# Altium schematic sheet motor_control.SchDoc
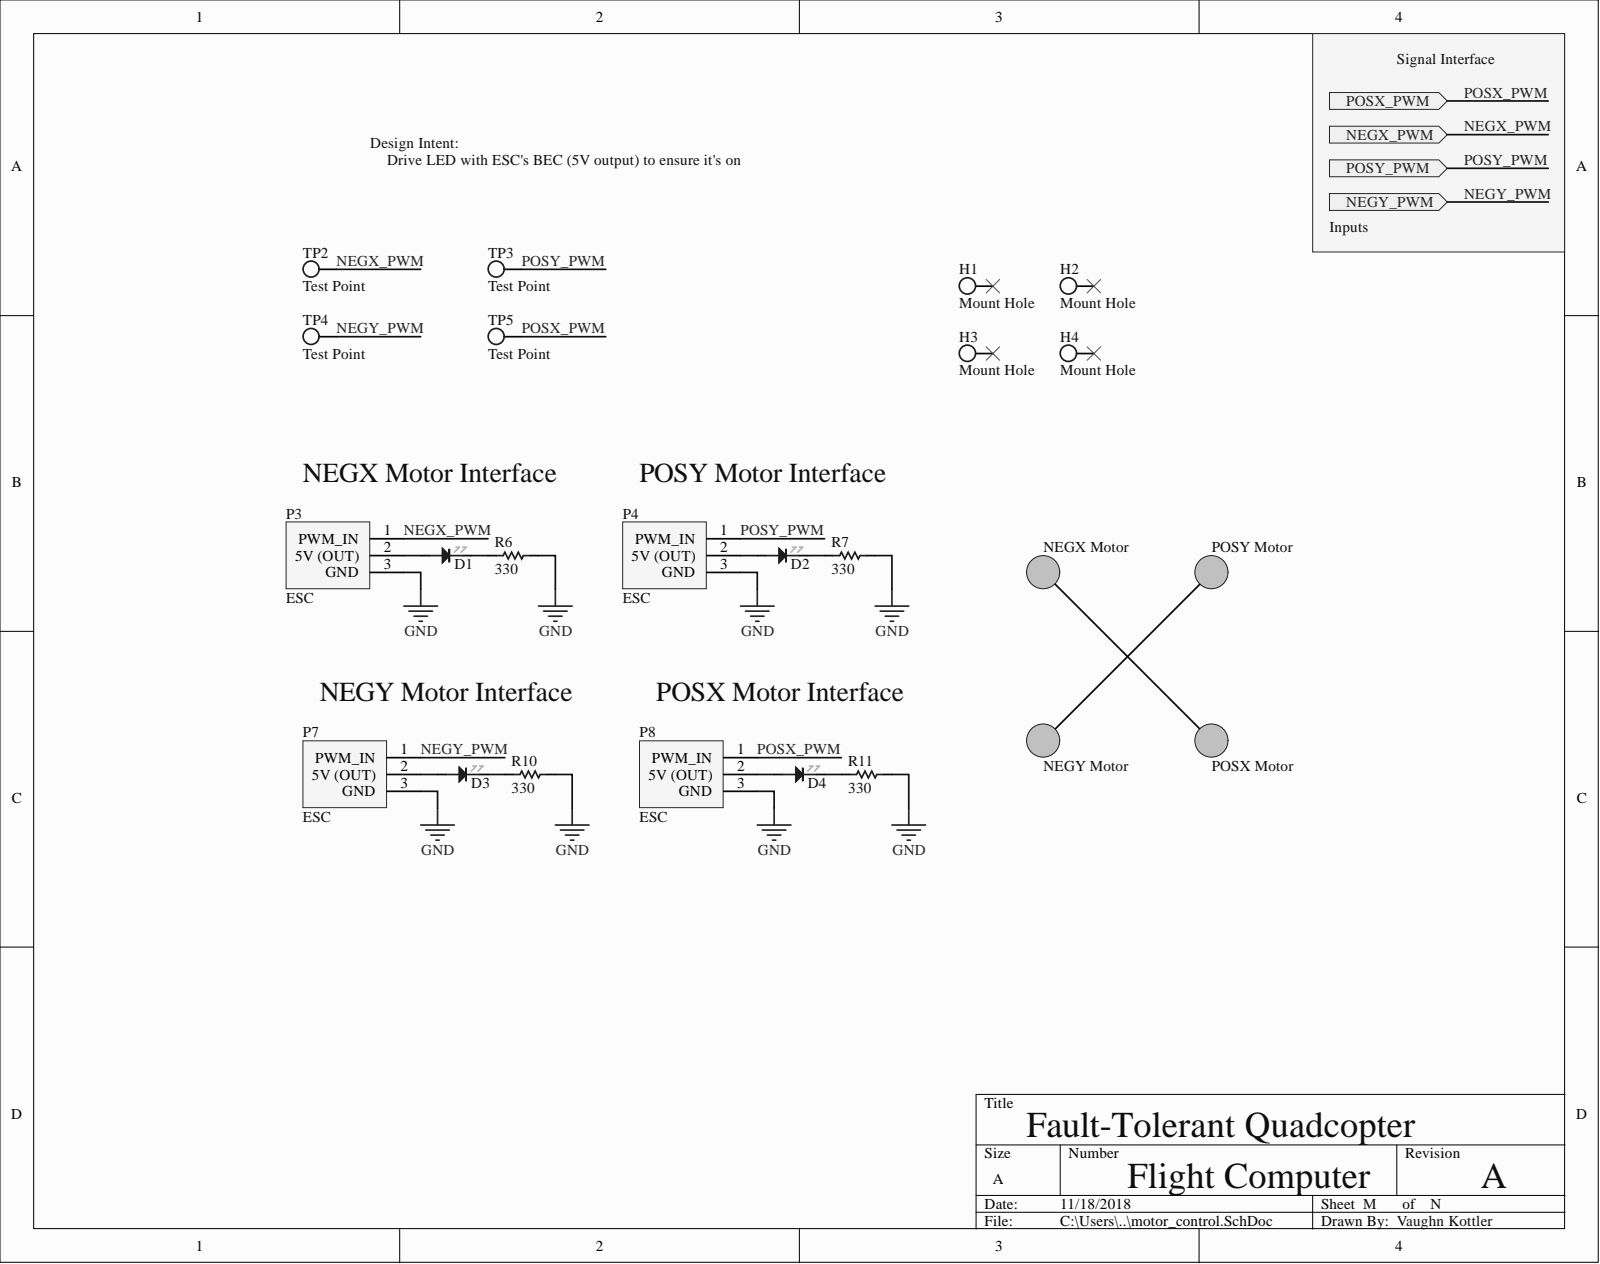
[diagram p0: motor_control.SchDoc]

## Parts
D1  LED-WHT-20mA  [LED-0805]  (value not drawn)
D2  LED-WHT-20mA  [LED-0805]  (value not drawn)
D3  LED-WHT-20mA  [LED-0805]  (value not drawn)
D4  LED-WHT-20mA  [LED-0805]  (value not drawn)
H1  Mount Hole  [4mm Mount Hole]
H2  Mount Hole  [4mm Mount Hole]
H3  Mount Hole  [4mm Mount Hole]
H4  Mount Hole  [4mm Mount Hole]
P3  ESC  [ESC RA]
P4  ESC  [ESC RA]
P7  ESC  [ESC RA]
P8  ESC  [ESC RA]
R6  330  [RES-0805]
R7  330  [RES-0805]
R10  330  [RES-0805]
R11  330  [RES-0805]
TP2  Test Point  [0603 Test Point]
TP3  Test Point  [0603 Test Point]
TP4  Test Point  [0603 Test Point]
TP5  Test Point  [0603 Test Point]

## Ports
NEGX_PWM, NEGY_PWM, POSX_PWM, POSY_PWM

## Nets
GND: P3.3 P4.3 P7.3 P8.3 R6.2 R7.2 R10.2 R11.2
NEGX_PWM: P3.1 TP2.1 ; port NEGX_PWM
NEGY_PWM: P7.1 TP4.1 ; port NEGY_PWM
POSX_PWM: P8.1 TP5.1 ; port POSX_PWM
POSY_PWM: P4.1 TP3.1 ; port POSY_PWM
NetD1_1: D1.1 P3.2
NetD1_2: D1.2 R6.1
NetD2_1: D2.1 P4.2
NetD2_2: D2.2 R7.1
NetD3_1: D3.1 P7.2
NetD3_2: D3.2 R10.1
NetD4_1: D4.1 P8.2
NetD4_2: D4.2 R11.1

Not connected: H1 H; H2 H; H3 H; H4 H

## Library data of the parts
D1-D4 = LED-WHT-20mA: 2.2V, 0805; Component Kind: Standard; ComponentLink1Description: Resistor Book (Amazon); ComponentLink1URL: https://www.amazon.com/gp/product/B071J21FYF/; Library Name: passive.SchLib; Supplier 1: Digi-Key; Supplier Part Number 1: 475-1410-1-ND
P3 P4 P7 P8 = ESC: PWM Interface, 0.1" Pitch 1x3; Component Kind: Standard; ComponentLink1Description: ESC + Motor Combo (Amazon); ComponentLink1URL: https://www.amazon.com/Hobbypower-1000kv-Brushless-Multicopter-Quadcopter/dp/B00E7LG85O/; Library Name: connector.SchLib
R6 R7 R10 R11 = RES-330-1/8W: 0805; Component Kind: Standard; ComponentLink1Description: Resistor Book (Amazon); ComponentLink1URL: https://www.amazon.com/gp/product/B071J21FYF/; Library Name: passive.SchLib
TP2-TP5 = Test Point: 0603; Component Kind: Standard; Library Name: passive.SchLib; Supplier 1: Digi-Key; Supplier Part Number 1: A106145DKR-ND
H1-H4 = Mount Hole: Component Kind: Standard; Library Name: connector.SchLib
